# Altium schematic sheet obc_main.SchDoc(obc_main)
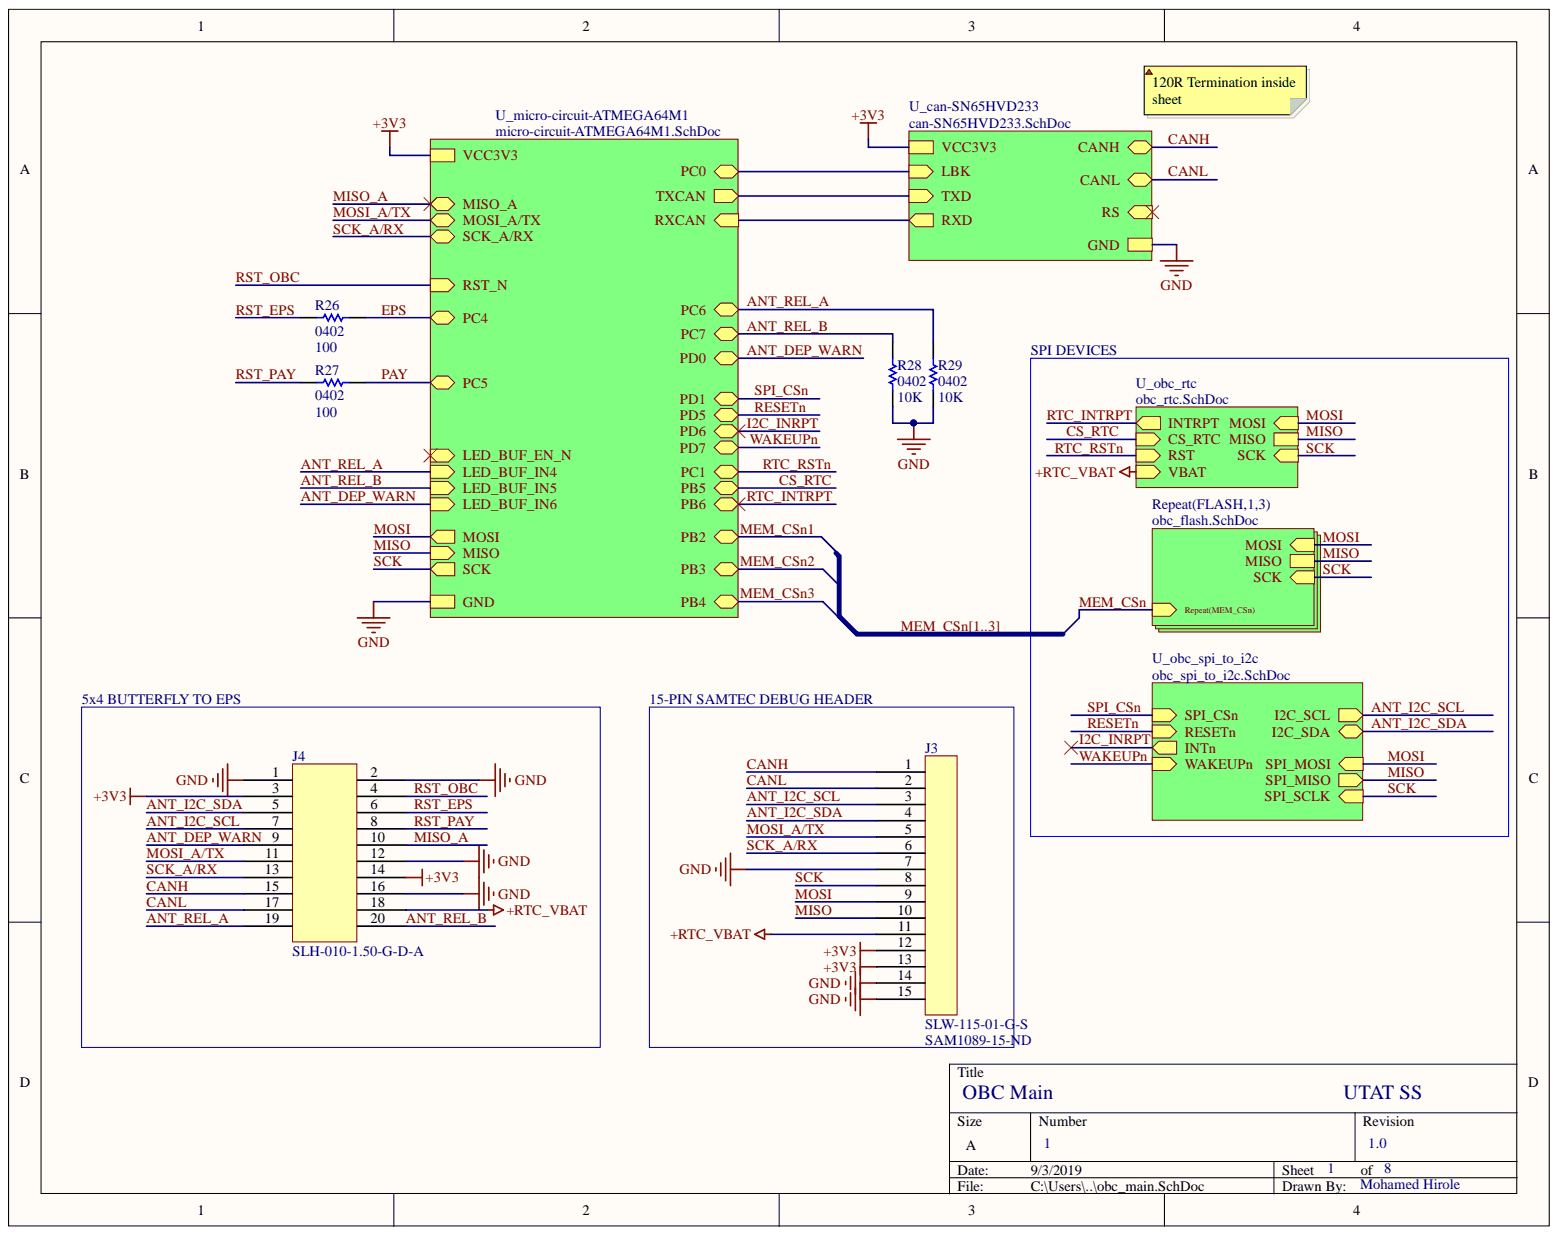
[diagram p0: obc_main.SchDoc]

## Parts
J3  SLW-115-01-G-S  [SAM1089-15-ND]
J4  SLH-010-1.50-G-D-A  [SLH-010-1.50-G-D-A]
R26  0402  [RES0402R]
R27  0402  value 100  [RES0402R]
R28  0402  value 10K  [RES0402R]
R29  0402  value 10K  [RES0402R]

## Nets
+3V3: J3.12 J3.13 J4.3 J4.14
+RTC_VBAT: J3.11 J4.18
GND: J3.7 J3.14 J3.15 J4.1 J4.2 J4.12 J4.16 R28.1 R29.1
ANT_DEP_WARN: J4.9
ANT_I2C_SCL: J3.3 J4.7
ANT_I2C_SDA: J3.4 J4.5
ANT_REL_A: J4.19 R29.2
ANT_REL_B: J4.20 R28.2
CANH: J3.1 J4.15
CANL: J3.2 J4.17
EPS: R26.2
MISO: J3.10
MISO_A: J4.10
MOSI: J3.9
MOSI_A/TX: J3.5 J4.11
PAY: R27.2
RST_EPS: J4.6 R26.1
RST_OBC: J4.4
RST_PAY: J4.8 R27.1
SCK: J3.8
SCK_A/RX: J3.6 J4.13
CS_RTC:
I2C_INRPT:
MEM_CSn1:  [also labelled MEM_CSN]
MEM_CSn2:  [also labelled MEM_CSN]
MEM_CSn3:  [also labelled MEM_CSN]
N00139:
N00140:
N00141:
N00142:
N00143:
RESETn:
RTC_INTRPT:
RTC_RSTn:
SPI_CSn:
WAKEUPn:

## Library data of the parts
J3 = SLW-115-01-G-S: 15 Position Receptacle Connector 0.100" (2.54mm) Through Hole Gold; Manufacturer: Samtec; Voltage Rating (AC): 550V; Number of Positions: 15; Max Current Rating: 7.1A; Gender: Female; Contact Resistance: 10mR; Width: 2.54mm; Termination: Solder; Supplier Part Number 1: SAM1089-15-ND; Supplier 1: Digi-Key; Stock 1: 0; Radiation Hardening: No; Pricing 1: 1=2.44, 10=2.214, 25=2.0784, 50=1.988, 100=1.8976, 250=1.71684, 500=1.5813 (USD); Pitch: 2.54mm; Packaging: Bulk; Package Quantity: 1; Orientation: Straight; Number of Rows: 1; Number of Contacts: 15; Mount: Through Hole; Min Operating Temperature: -55°C; Max Voltage Rating (AC): 550V; Max Operating Temperature: 125°C; Manufacturer Part Number: SLW-115-01-G-S; Length: 38.1mm; Lead Free: Lead Free; Housing Material: Polyester; Housing Color: Black; Depth: 2.54mm; Contact Plating: Gold; Contact Material: Bronze; Connector Type: Receptacle; ComponentLink1URL: http://suddendocs.samtec.com/prints/slw-1xx-01-x-x-mkt.pdf?src-supplier=Digi-Key; ComponentLink1Description: http://suddendocs.samtec.com/prints/slw-1xx-01-x-x-mkt.pdf?src-supplier=Digi-Key; Component Kind: Standard; Color: Black; Library Name: heron-general.IntLib
J4 = SLH-010-1.50-G-D-A: 0.50 mm Razor Beam™ LP Ultra Low Profile Socket Strip, alignment pin option; Supplier Part Number 1: Samtec; Supplier 1: SLH-010-1.50-G-D-A; ComponentLink1URL: https://www.samtec.com/products/slh-010-1.50-g-d-a; ComponentLink1Description: Product Page; Component Kind: Standard; Library Name: heron-general.IntLib
R26 = RES0402: 0402 resistor; Value: 100; Simulation: RESISTOR; Signal Integrity: Res; Component Kind: Standard; Library Name: heron-general.IntLib
R27-R29 = RES0402: 0402 resistor; Simulation: RESISTOR; Signal Integrity: Res; Component Kind: Standard; Library Name: heron-general.IntLib
